# Altium schematic sheet USB_to_IRDA.SchDoc(USB_to_IRDA)
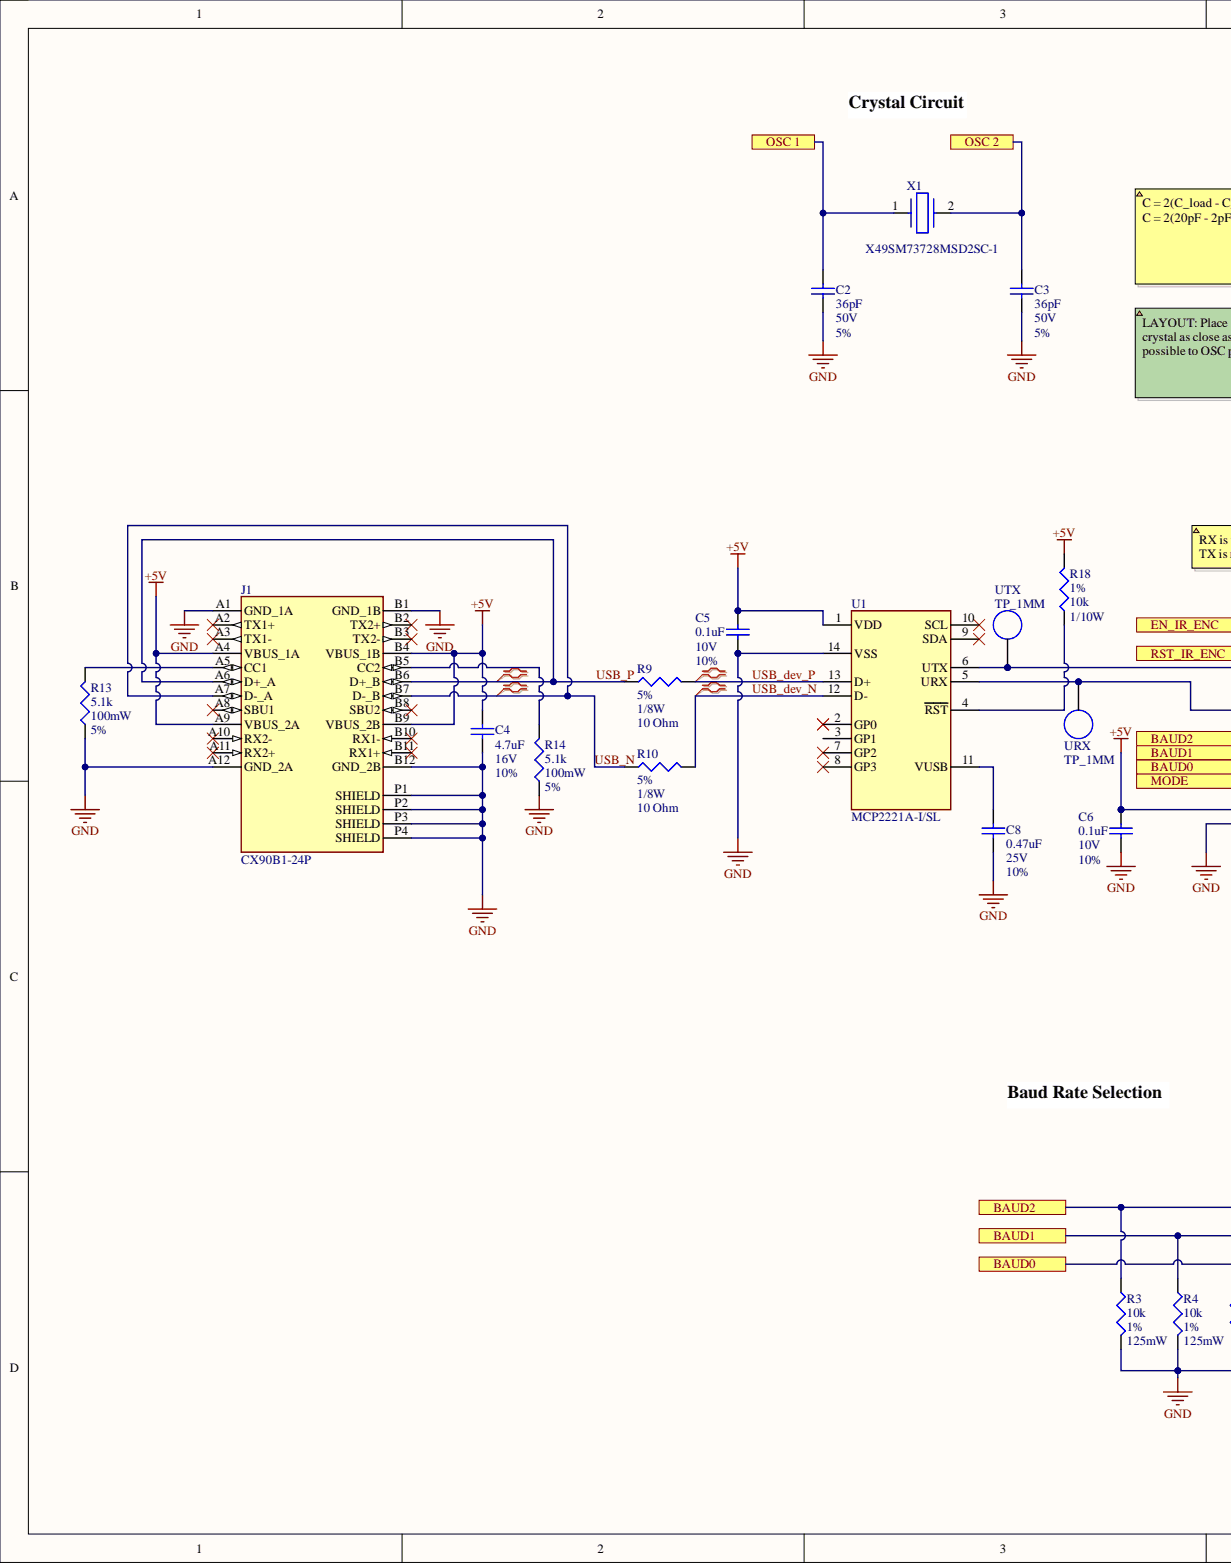
[diagram p0: USB_to_IRDA.SchDoc, left]
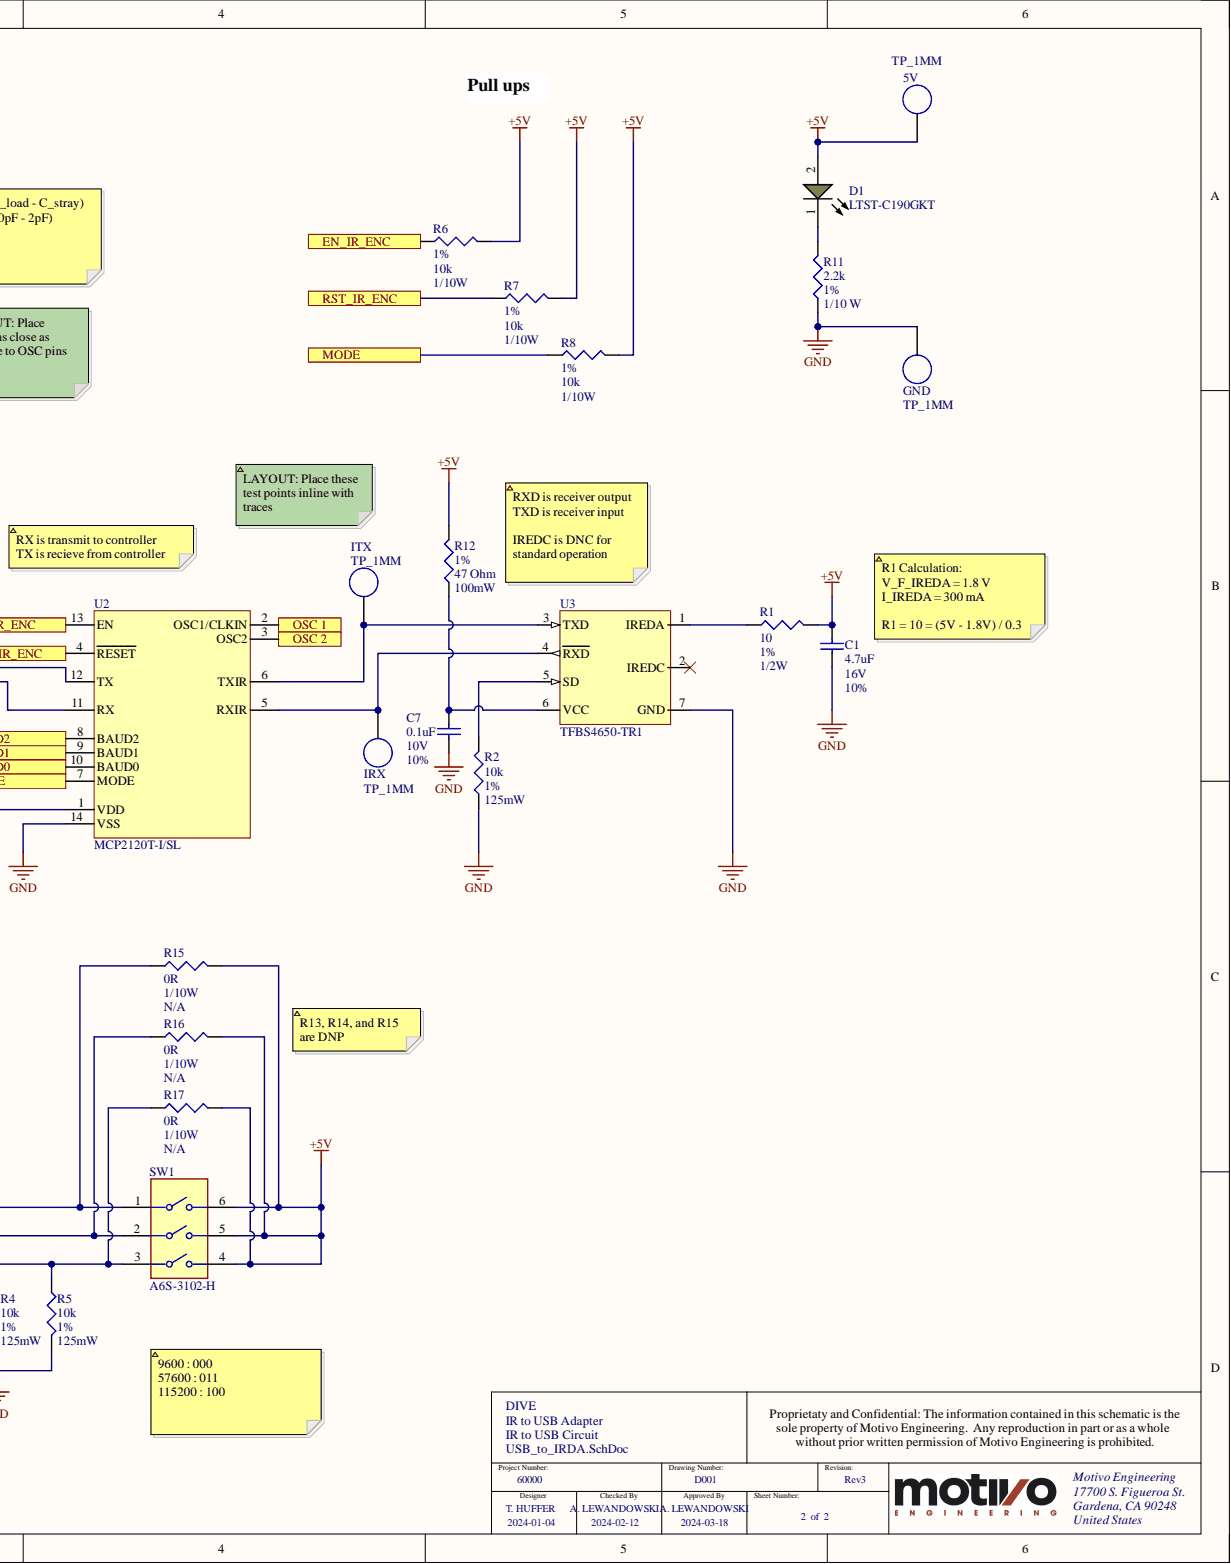
[diagram p1: USB_to_IRDA.SchDoc, right]

## Parts
5V  TP_1MM  [TP-SM-C-100]  (no BOM)
C1  Capacitance: 4.7uF  Tolerance: 10%  Voltage: 16V  [CAP-0603-MD]  (hidden comment: C1608X5R1C475K080AC)
C2  value 36pF  Capacitance: 36pF  Tolerance: 5%  Voltage: 50V  [CAP-0402-MD]  (hidden comment: CC0402JRNPO9BN360)
C3  value 36pF  Capacitance: 36pF  Tolerance: 5%  Voltage: 50V  [CAP-0402-MD]  (hidden comment: CC0402JRNPO9BN360)
C4  Capacitance: 4.7uF  Tolerance: 10%  Voltage: 16V  [CAP-0603-MD]  (hidden comment: C1608X5R1C475K080AC)
C5  Capacitance: 0.1uF  Tolerance: 10%  Voltage: 10V  [CAP-0603-MD]  (hidden comment: 885012206020)
C6  Capacitance: 0.1uF  Tolerance: 10%  Voltage: 10V  [CAP-0603-MD]  (hidden comment: 885012206020)
C7  Capacitance: 0.1uF  Tolerance: 10%  Voltage: 10V  [CAP-0603-MD]  (hidden comment: 885012206020)
C8  Capacitance: 0.47uF  Tolerance: 10%  Voltage: 25V  [CAP-0603-MD]  (hidden comment: CL10B474KA8VPNC)
D1  LTST-C190GKT  [LED-0603]
GND  TP_1MM  [TP-SM-C-100]  (no BOM)
IRX  TP_1MM  [TP-SM-C-100]  (no BOM)
ITX  TP_1MM  [TP-SM-C-100]  (no BOM)
J1  CX90B1-24P  [HRS_CX90B1-24P]
R1  Power: 1/2W  Tolerance: 1%  [RES-1206-MD]  (hidden comment: RNCP1206FTD10R0)
R2  Max Power Dissipation: 125mW  Resistance: 10k  Resistance Tolerance: 1%  [RES-0805-MD]  (hidden comment: RC0805FR-0710KL)
R3  Max Power Dissipation: 125mW  Resistance: 10k  Resistance Tolerance: 1%  [RES-0805-MD]  (hidden comment: RC0805FR-0710KL)
R4  Max Power Dissipation: 125mW  Resistance: 10k  Resistance Tolerance: 1%  [RES-0805-MD]  (hidden comment: RC0805FR-0710KL)
R5  Max Power Dissipation: 125mW  Resistance: 10k  Resistance Tolerance: 1%  [RES-0805-MD]  (hidden comment: RC0805FR-0710KL)
R6  Resistance: 10k  Tolerance: 1%  [RES-0603-MD]  (hidden comment: RC0603FR-0710KL)
R7  Power: 1/10W  Resistance: 10k  Tolerance: 1%  [RES-0603-MD]  (hidden comment: RC0603FR-0710KL)
R8  Power: 1/10W  Resistance: 10k  Tolerance: 1%  [RES-0603-MD]  (hidden comment: RC0603FR-0710KL)
R9  Power: 1/8W  Tolerance: 5%  [RES-0805-MD]  (hidden comment: CRCW080510R0JNEA)
R10  Power: 1/8W  Resistance: 10 Ohm  Tolerance: 5%  [RES-0805-MD]  (hidden comment: CRCW080510R0JNEA)
R11  Power: 1/10 W  Resistance: 2.2k  Tolerance: 1%  [RES-0603-MD]  (hidden comment: RC0603FR-072K2L)
R12  Power: 100mW  Resistance: 47 Ohm  Tolerance: 1%  [RES-0603-MD]  (hidden comment: RMCF0603FT47R0)
R13  Max Power Dissipation: 100mW  Resistance: 5.1k  Resistance Tolerance: 5%  [RES-0603-MD]  (hidden comment: CRCW06035K10JNEA)
R14  Max Power Dissipation: 100mW  Resistance: 5.1k  Resistance Tolerance: 5%  [RES-0603-MD]  (hidden comment: CRCW06035K10JNEA)
R15  Power: 1/10W  Resistance: 0R  [RES-0603-MD]  (hidden comment: MCR03ERTJ000)
R16  Power: 1/10W  Resistance: 0R  [RES-0603-MD]  (hidden comment: MCR03ERTJ000)
R17  Power: 1/10W  Resistance: 0R  [RES-0603-MD]  (hidden comment: MCR03ERTJ000)
R18  Power: 1/10W  Resistance: 10k  Tolerance: 1%  [RES-0603-MD]  (hidden comment: RC0603FR-0710KL)
SW1  A6S-3102-H  [FP-A6S-3102-H-MFG]
U1  MCP2221A-I/SL  [SOIC127P600X175-14N]
U2  MCP2120T-I/SL  [SOIC127P600X175-14N]
U3  TFBS4650-TR1  [TFBS4650-TR1-Footprint-1]
URX  TP_1MM  [TP-SM-C-100]  (no BOM)
UTX  TP_1MM  [TP-SM-C-100]  (no BOM)
X1  X49SM73728MSD2SC-1  [X49SM73728MSD2SC-1-Footprint-1]

## Ports
BAUD0, BAUD1, BAUD2, EN_IR_ENC, MODE, OSC 1, OSC 2, RST_IR_ENC

## Nets
+5V: 5V.1 C1.2 C4.2 C5.1 C6.1 D1.2 J1.A4(VBUS_1A) J1.A9(VBUS_2A) J1.B4(VBUS_1B) J1.B9(VBUS_2B) R1.2 R6.2 R7.2 R8.2 R12.2 R15.2 R16.2 R17.2 R18.2 SW1.4 SW1.5 SW1.6 U1.1(VDD) U2.1(VDD)
GND: C1.1 C2.1 C3.2 C4.1 C5.2 C6.2 C7.2 C8.1 GND.1 J1.A1(GND_1A) J1.A12(GND_2A) J1.B1(GND_1B) J1.B12(GND_2B) J1.P1(SHIELD) J1.P2(SHIELD) J1.P3(SHIELD) J1.P4(SHIELD) R2.1 R3.2 R4.2 R5.2 R11.1 R13.1 R14.1 U1.14(VSS) U2.14(VSS) U3.7(GND)
BAUD0: R5.1 R17.1 SW1.3 U2.10(BAUD0) ; port BAUD0
BAUD1: R4.1 R16.1 SW1.2 U2.9(BAUD1) ; port BAUD1
BAUD2: R3.1 R15.1 SW1.1 U2.8(BAUD2) ; port BAUD2
EN_IR_ENC: R6.1 U2.13(EN) ; port EN_IR_ENC
MODE: R8.1 U2.7(MODE) ; port MODE
OSC 1: C2.2 U2.2(OSC1/CLKIN) X1.1 ; port OSC 1
OSC 2: C3.1 U2.3(OSC2) X1.2 ; port OSC 2
RST_IR_ENC: R7.1 U2.4(RESET) ; port RST_IR_ENC
USB_dev_N: R10.2 U1.12(D-)
USB_dev_P: R9.2 U1.13(D+)
USB_N: J1.A7(D-_A) J1.B7(D-_B) R10.1
USB_P: J1.A6(D+_A) J1.B6(D+_B) R9.1
NetC7_1: C7.1 R12.1 U3.6(VCC)
NetC8_2: C8.2 U1.11(VUSB)
NetD1_1: D1.1 R11.2
NetIRX_1: IRX.1 U2.5(RXIR) U3.4(RXD)
NetITX_1: ITX.1 U2.6(TXIR) U3.3(TXD)
NetJ1_A5: J1.A5(CC1) R13.2
NetJ1_B5: J1.B5(CC2) R14.2
NetR1_1: R1.1 U3.1(IREDA)
NetR2_2: R2.2 U3.5(SD)
NetR18_1: R18.1 U1.4(RST)
NetU1_5: U1.5(URX) U2.11(RX) URX.1
NetU1_6: U1.6(UTX) U2.12(TX) UTX.1

Not connected: J1 A2(TX1+) A3(TX1-) A8(SBU1) A10(RX2-) A11(RX2+) B2(TX2+) B3(TX2-) B8(SBU2) B10(RX1-) B11(RX1+); U1 2(GP0) 3(GP1) 7(GP2) 8(GP3) 9(SDA) 10(SCL); U3 2(IREDC)

## Library data of the parts
5V GND IRX ITX URX UTX = CMP-084-000000-1: Test Point 1MM; CheckedDate: 2/7/2020; CreatedDate: 2/1/2020; ManufacturerPartNumber: TP_1MM; Pin Count: 1; CheckedBy: David Volovskiy; CreatedBy: Andrew Ameri; Library Name: Motivo Engineering
C1 C4 = CMP-009-000081-8: CAP CER 4.7UF 16V X5R 0603; Manufacturer: TDK; Case Code (Imperial): 0603; Case Code (Metric): 1608; Case/Package: 0603; CheckedDate: 4/4/2018; Component Kind: Standard; CreatedDate: 5/10/2018; Dielectric: X5R; CheckedBy: Daniel Samarin; Library Name: Motivo Engineering
C2 C3 = CMP-002-00422-4: CAP CER 36PF ±5% 50V C0G/NPO 0402; Dielectric: NP0; Case Code (Imperial): 0402; Case Code (Metric): 1005; Case/Package: 0402; CheckedDate: 1/2/2023; Component Kind: Standard; Lead Free: Lead Free; Length: 0.039inch; ManufacturerPartNumber: CC0402JRNPO9BN360; Max Operating Temperature: 125°C; Min Operating Temperature: -55°C; Mounting Technology: Surface Mount; Package Quantity: 10000; Packaging: Tape and Reel; RoHS Compliant: Yes; Series: CC; Termination: SMD/SMT; Thickness: 0.022inch; Weight: 2.3E-05oz; Width: 0.02inch; CheckedBy: AGL; Library Name: Motivo Engineering
C5-C7 = CMP-009-000024-8: CAP CER 0.1UF 10V X7R 0603; Manufacturer: Wurth Electronics; Case Code (Imperial): 0603; Case Code (Metric): 1608; Case/Package: 0603; CheckedDate: 4/4/2018; Component Kind: Standard; ComponentLink1Description: Datasheet; ComponentLink1URL: https://search.kemet.com/component-edge/download/datasheet/C0603C104K8PAC7867.pdf; CreatedDate: 5/2/2018; Dielectric: X7R; CheckedBy: Daniel Samarin; Library Name: Motivo Engineering
C8 = CMP-009-000137-8: CAP CER 0.47UF 25V X7R 0603; Manufacturer: Samsung Electro-Mechanics; Case Code (Imperial): 0603; Case Code (Metric): 1608; Case/Package: 0603; Component Kind: Standard; CreatedDate: 12/27/2020; Dielectric: X7R; Library Name: Motivo Engineering
D1 = CMP-024-000054-4: LED GREEN CLEAR CHIP SMD; Manufacturer: Lite-On Inc; Created_By: Tejaswi Gode; CurrentMax: 120mA; Dominant Wavelength: 569nm; Forward Current: 30mA; Forward Voltage: 2.1V; Lens Color: Colorless; Lens Size: 0.8mm; Lens Style: Flat,Diffused,Colorless,Rectangular,Clear; Luminous Intensity: 6mcd; Number of Elements: 1; Power Dissipation: 100mW; Reverse Voltage: 5V; Test Current: 10mA; Viewing Angle: 130°; Voltage: 2V; Wavelength: 565nm; Case Code (Imperial): 0603; Case Code (Metric): 1608; Case/Package: 0603; CheckedDate: 2/12/2024; Color: Green; Component Kind: Standard; ComponentLink1Description: Datasheet; ComponentLink1URL: http://media.digikey.com/pdf/Data%20Sheets/Lite-On%20PDFs/LTST-C190GKT.pdf; Created_Date: 9/8/2016; Depth: 0.8mm; Height: 0.9mm; Illumination Color: Green; Lead Free: Lead Free; LEDColor: Green; Length: 1.6mm; Lens Transparency: Clear; ManufacturerPartNumber: LTST-C190GKT; Max Operating Temperature: 85°C; Min Operating Temperature: -55°C; Mounting Technology: Surface Mount; Number of LEDs: 1; Package Quantity: 1; Packaging: Tape and Reel; Pin Count: 2; Pins: 2; Power Rating: 100mW; Radiation Hardening: No; RoHS Compliant: Yes; Series: -; Size: 0603; Vforward: 2.1V; Width: 0.8mm; CheckedBy: AGL; Library Name: Motivo Engineering
J1 = CMP-144-000000-4: CONN RCP USB3.1 TYPEC 24P SMD RA; Connector Type: USB; Gender: Receptacle; Mating Cycles: 10000; Number of Contacts: 24; Number of Ports: 1; Shielding: Shielded; CheckedDate: 2/13/2024; Component Kind: Standard; Component Type: Standard; Contact Plating: Gold; Design Item ID: CX90B1-24P; Flammability Rating: UL94 V-0; Insulation Color: Black; Max Operating Temperature: 85°C; Min Operating Temperature: -30°C; Mounting Technology: Surface Mount,Through Hole,Horizontal; Packaging: Cut Tape; Pin Count: 28; RoHS Compliant: Yes; Series: CX; Source: CX90B1-24P.SchLib; Termination: Solder; CheckedBy: AGL; Library Name: Motivo Engineering
R1 = CMP-049-000331-23: RES 10 OHM 1% 1/2W 1206; Resistance: 10; Case Code (Imperial): 1206; Case Code (Metric): 3216; Case/Package: 1206; CheckedDate: 1/2/2024; Component Kind: Standard; ComponentLink1Description: Datasheet; ComponentLink1URL: https://www.seielect.com/Catalog/SEI-rncp.pdf; CreatedDate: 10/15/2020; Size: 1206; CheckedBy: AGL; Library Name: Motivo Engineering
R2-R5 = CMP-049-000214-23: RES 10K OHM 1% 1/8W 0805; Temperature Coefficient: 100ppm/°C; Voltage Rating: 150V; Case Code (Imperial): 0805; Case Code (Metric): 2012; Case/Package: 0805; CheckedDate: 1/2/2024; Component Kind: Standard; Construction: Rectangular; Depth: 1.25mm; Features: Moisture Resistant; Height: 0.024inch; Lead Free: Lead Free; Length: 0.079inch; Max Operating Temperature: 155°C; Military Standard: Not; Min Operating Temperature: -55°C; Number of Terminations: 2; Package Quantity: 1; Packaging: Tape and Reel; Power: 125mW; Resistor Type: Thick Film; RoHS Compliant: Yes; Series: RC; Technology: THICK FILM; Termination: SMD/SMT; Tolerance: 1%; Width: 0.049inch; CheckedBy: AGL; Library Name: Motivo Engineering
R6-R8 R18 = CMP-049-000171-20: RES SMD 10K OHM 1% 1/10W 0603; CheckedDate: 1/20/2022; Component Kind: Standard; CreatedDate: 6/11/2017; Size: 0603; CheckedBy: Chris Verdegan; Library Name: Motivo Engineering
  R6: Power: 1/10W
R9 = CMP-049-000073-22: RES SMD 10 OHM 5% 1/8W 0805; Resistance: 10 Ohm; Case Code (Imperial): 0805; Case Code (Metric): 2012; Case/Package: 0805; CheckedDate: 1/2/2024; Component Kind: Standard; ComponentLink1Description: Datasheet; ComponentLink1URL: http://www.vishay.com/docs/20035/dcrcwe3.pdf; CreatedDate: 9/14/2016; Size: 0805; CheckedBy: AGL; Library Name: Motivo Engineering
R10 = CMP-049-000073-22: RES SMD 10 OHM 5% 1/8W 0805; Case Code (Imperial): 0805; Case Code (Metric): 2012; Case/Package: 0805; CheckedDate: 1/2/2024; Component Kind: Standard; ComponentLink1Description: Datasheet; ComponentLink1URL: http://www.vishay.com/docs/20035/dcrcwe3.pdf; CreatedDate: 9/14/2016; Size: 0805; CheckedBy: AGL; Library Name: Motivo Engineering
R11 = CMP-049-000186-20: RES 2.20K OHM 1/10W 1% 0603 SMD; CheckedDate: 6/29/2014; Component Kind: Standard; ComponentLink1Description: Datasheet; ComponentLink1URL: http://www.yageo.com/documents/recent/PYu-RC0603_51_RoHS_L_4.pdf; CreatedDate: 6/4/2014; Size: 0603; CheckedBy: Daniel Samarin; Library Name: Motivo Engineering
R12 = CMP-011-00087-5: RES 47 OHM 1% 1/10W 0603; Temperature Coefficient: 100ppm/°C; Voltage Rating: 75V; Case Code (Imperial): 0603; Case Code (Metric): 1608; Case/Package: 0603; Component Kind: Standard; Contact Plating: Tin,Matte; Features: Automotive AEC-Q200; Height: 0.022inch; Length: 0.061inch; Max Operating Temperature: 155°C; Min Operating Temperature: -55°C; Mounting Technology: Surface Mount; Number of Terminations: 2; Packaging: Tape and Reel; Radiation Hardening: No; RoHS Compliant: Yes; Series: RMCF; Width: 0.031inch; Library Name: Motivo Engineering
R13 R14 = CMP-049-000065-21: RES SMD 5.1K OHM 5% 1/10W 0603; Temperature Coefficient: 200ppm/°C; Voltage Rating: 75V; Case Code (Imperial): 0603; Case Code (Metric): 1608; Case/Package: 0603; Component Kind: Standard; ComponentLink1Description: Datasheet; ComponentLink1URL: http://www.vishay.com/docs/20035/dcrcwe3.pdf; Construction: Rectangular; Contact Plating: Tin; CreatedDate: 9/9/2016; Depth: 0.85mm; Features: Automotive AEC-Q200; Height: 0.45mm; Lead Free: Lead Free; Length: 1.55mm; Max Operating Temperature: 155°C; Military Standard: Not; Min Operating Temperature: -55°C; Number of Terminations: 2; Package Quantity: 5000; Packaging: Tape and Reel; Pins: 2; Power: 100mW; Power Rating: 100mW; Radiation Hardening: No; Ratings: AEC-Q200; Reach SVHC Compliant: Unknown; Resistor Type: Thick Film; RoHS Compliant: Yes; Series: CRCW; Size: 0603; Termination: SMD/SMT; Tolerance: 5%; Voltage Rating (DC): 75V; Weight: 7.1E-05oz; Width: 0.85mm; Library Name: Motivo Engineering
R15-R17 = CMP-049-000159-22: RES SMD 0.0OHM JUMPER 1/10W 0603; Max Power Dissipation: 100mW; Voltage Rating (DC): 50V; Case Code (Imperial): 0603; Case Code (Metric): 1608; Case/Package: 0603; Component Kind: Standard; CreatedDate: 8/15/2016; Height: 0.022inch; Lead Free: Lead Free; Length: 0.063inch; Max Operating Temperature: 155°C; Min Operating Temperature: -55°C; Number of Terminations: 2; Package Quantity: 1; Packaging: Cut Tape; RoHS Compliant: Yes; Series: MCR; Size: 0603; Tolerance: N/A; Width: 0.031inch; Library Name: Motivo Engineering
SW1 = CMP-012-00011-2: SWITCH SLIDE DIP SPST 25MA 24V; Actuator Length: 0mm; Actuator Type: Slide (Standard); Contact Resistance: 200mR; Electrical Life: 1000Cycles; Number of Circuits: 3; Washable: Yes; Case/Package: DIP; CheckedDate: 1/2/2024; Component Kind: Standard; Contact Current Rating: 25mA; Contact Material: Gold; Contact Plating: Gold; Depth: 9.8mm; Length: 8.56mm; Max Current Rating: 25mA; Max Operating Temperature: 70°C; Max Voltage Rating (DC): 24V; Mechanical Life: 1000Cycles; Min Operating Temperature: -20°C; Mounting Technology: Surface Mount; Number of Positions: 3; Orientation: Straight; Package Quantity: 55; Packaging: Rail/Tube; Radiation Hardening: No; Reach SVHC Compliant: Unknown; RoHS Compliant: Yes; Series: A6S-H; Terminal Pitch: 2.54mm; Termination: Gull Wing; Throw Configuration: SPST; Voltage Rating (DC): 24V; CheckedBy: AGL; Library Name: Motivo Engineering
U1 = CMP-016-00019-4: IC USB TO I2C/UART 14SOIC; Interface: I2C,UART; Operating Supply Current: 13mA; Case/Package: SOIC; Component Kind: Standard; Max Operating Temperature: 85°C; Min Operating Temperature: -40°C; Packaging: Rail/Tube; RoHS Compliant: Yes; Series: -; Library Name: Motivo Engineering
U2 = CMP-016-00020-5: IC ENCODR/DECODR 2.5V IR 14-SOIC; Max Supply Voltage: 5.5V; Nominal Supply Current: 1.4mA; Number of Bits: 16; Number of Channels: 1; Power Dissipation: 700mW; Touchscreen: Infrared (IR); Voltage: 5V; Case/Package: SOIC; Component Kind: Standard; Lead Free: Lead Free; Max Operating Temperature: 85°C; Min Operating Temperature: -40°C; Min Supply Voltage: 2.5V; Mounting Technology: Surface Mount; Package Quantity: 2600; Packaging: Tape and Reel; Pins: 14; Radiation Hardening: No; RoHS Compliant: Yes; Series: -; CheckedBy: A.DANG; Library Name: Motivo Engineering
U3 = CMP-025-00000-1: TXRX IRDA 115.2KBIT SIDE 7-SMD / Infrared Transceiver 9.6 kbit/s to 115.2 kbit/s (SIR); Component Kind: Standard; Library Name: Motivo Engineering
X1 = CMP-003-00033-1: 7.3728MHz 20pF ±20ppm HC-49S-SMD Crystals ROHS; Capacitanace: 20 pF; Component Kind: Standard; Value: 7.3728 MHz; Library Name: Motivo Engineering
